# Altium schematic sheet TIMER.SchDoc
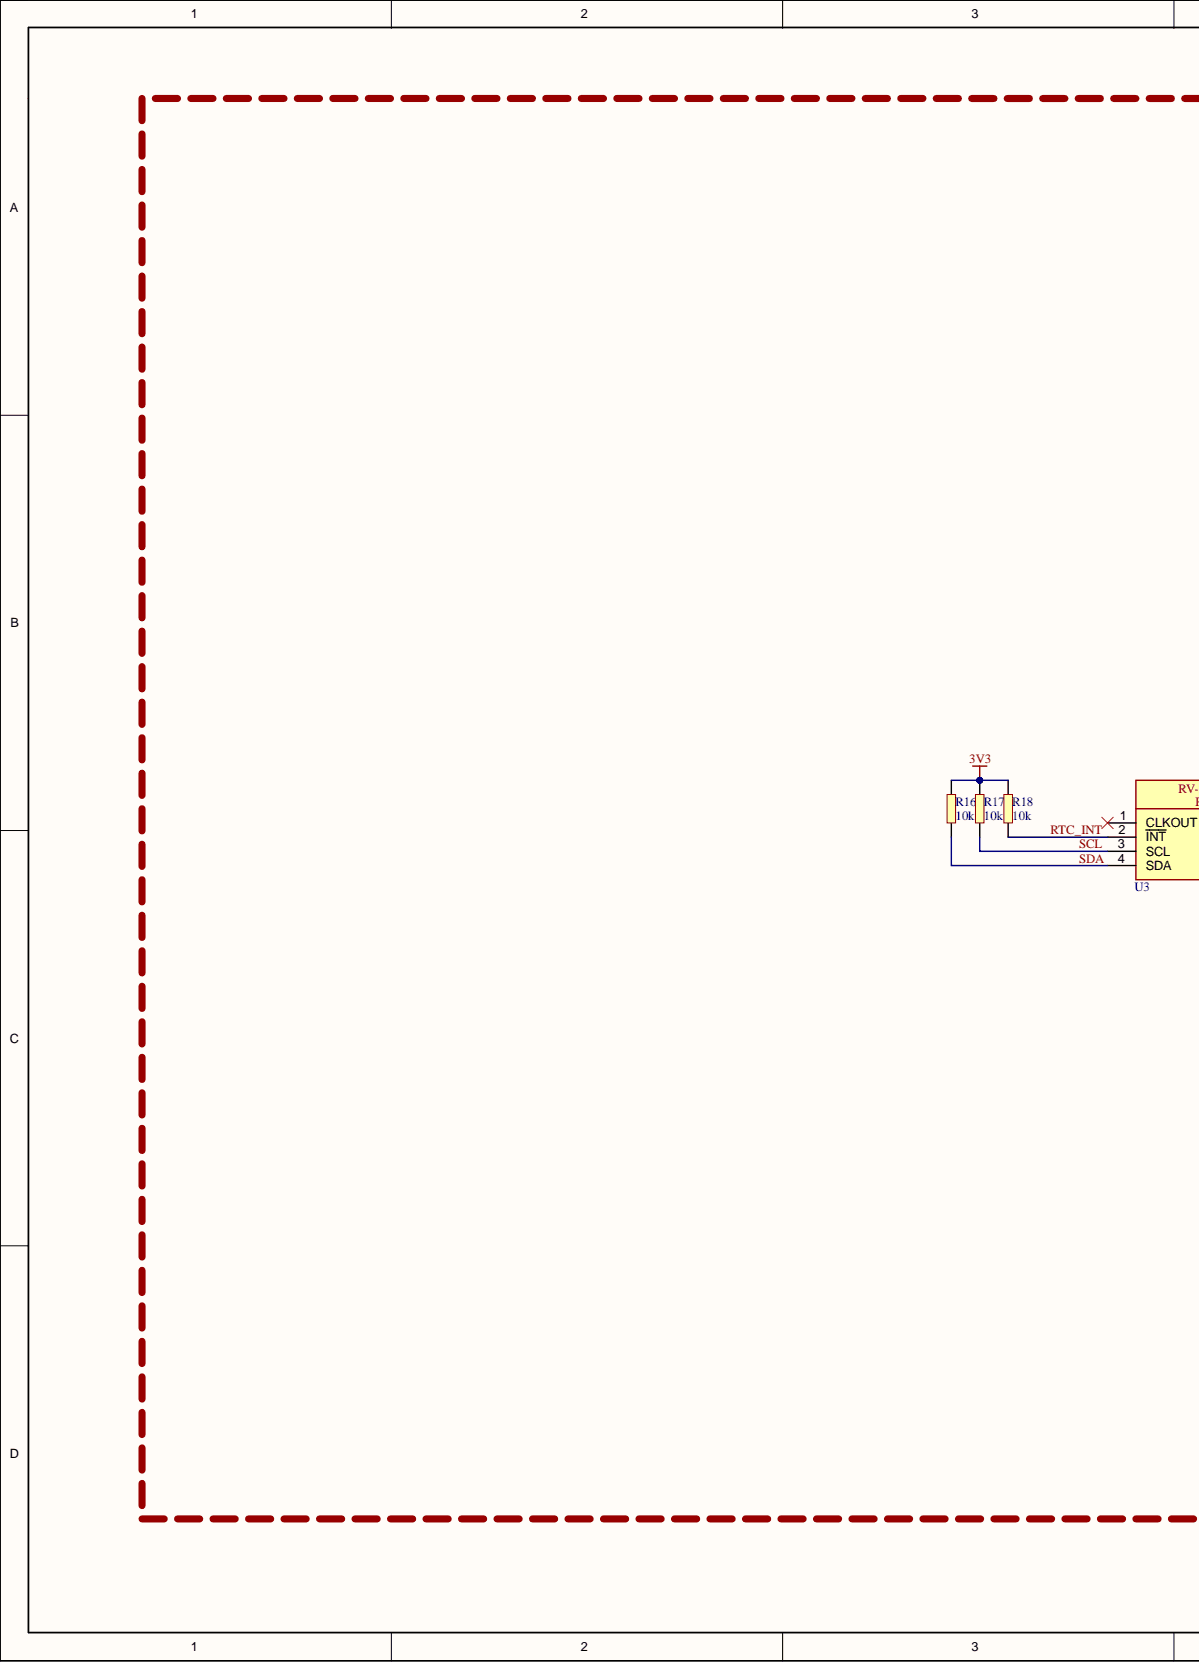
[diagram p0: TIMER.SchDoc, left]
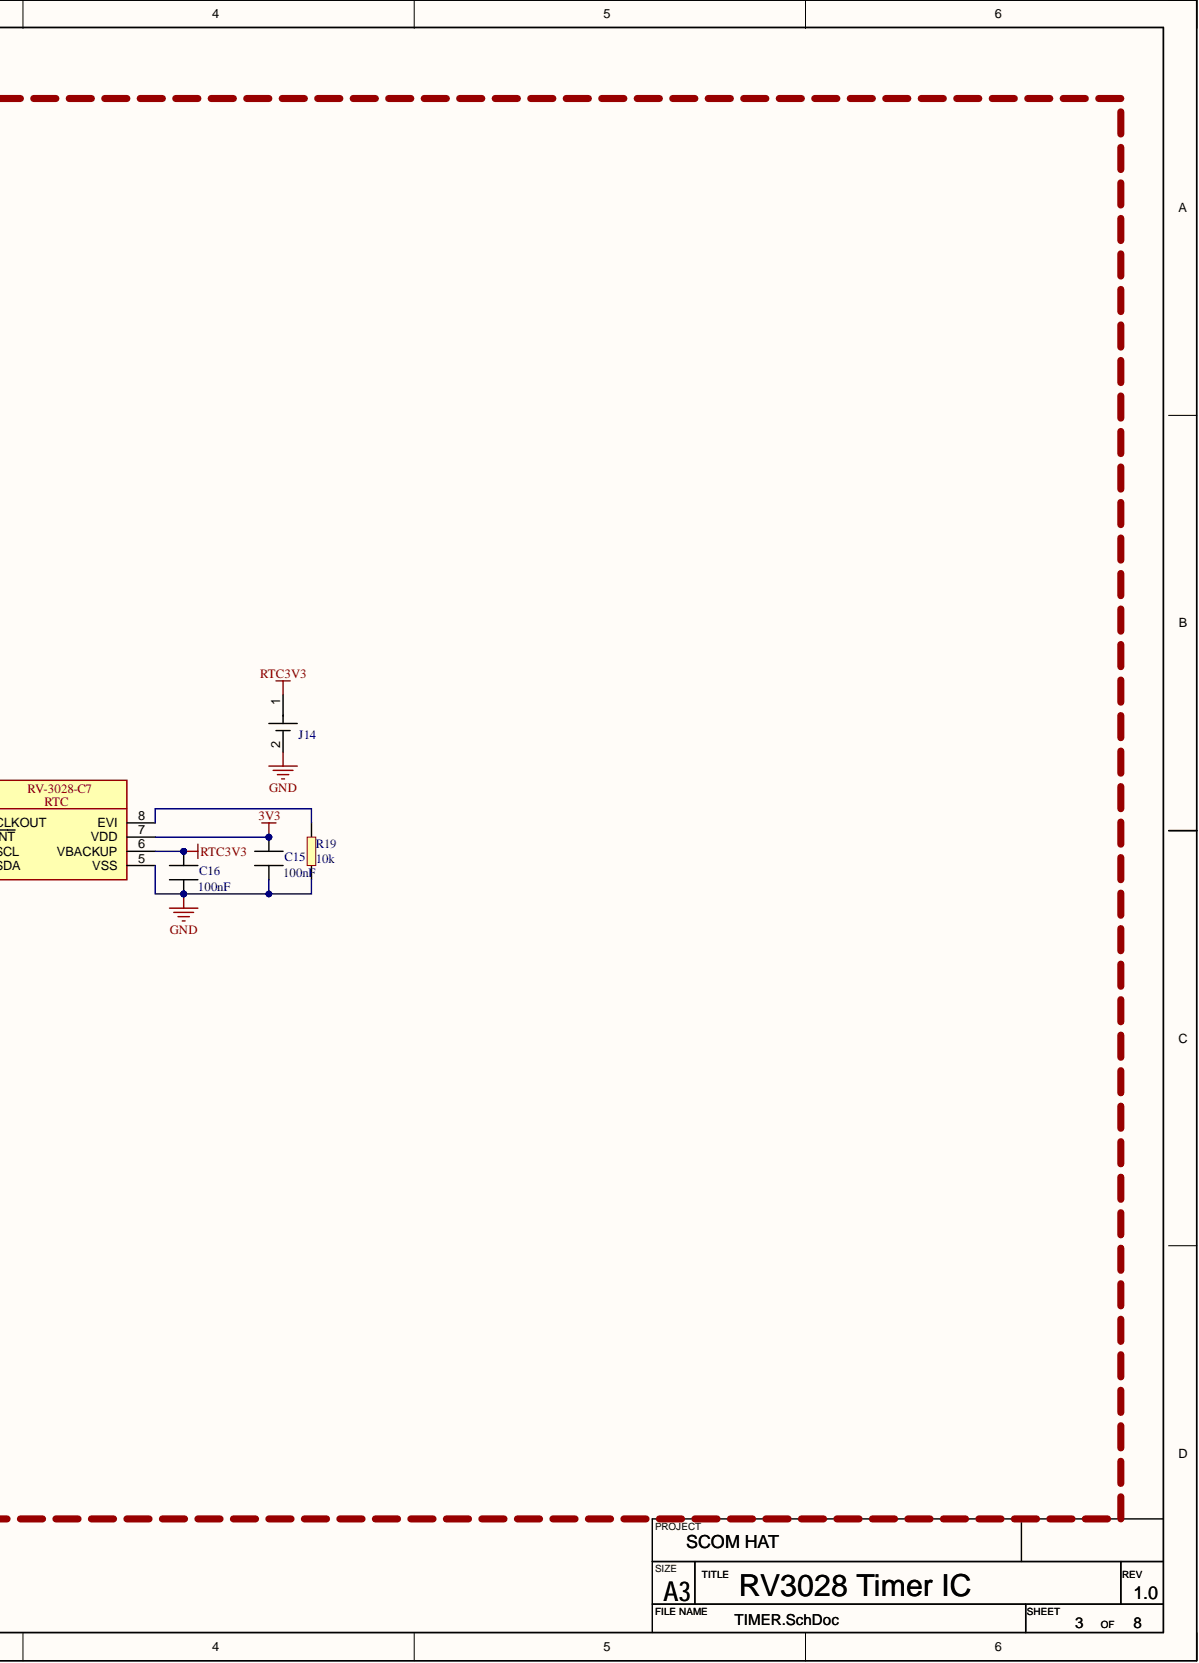
[diagram p1: TIMER.SchDoc, right]

## Parts
C15  value 100nF  [CAPC2012X13L]  (hidden comment: MLCC_0805)
C16  value 100nF  [CAPC2012X13L]  (hidden comment: MLCC_0805)
J14  CR1220-BH  [CR1220-BH]  (value not drawn)
R16  value 10k  [RES_0603]  (hidden comment: ERP_101-1)
R17  value 10k  [RES_0603]  (hidden comment: ERP_101-1)
R18  value 10k  [RES_0603]  (hidden comment: ERP_101-1)
R19  value 10k  [RES_0603]  (hidden comment: ERP_101-1)
U3  RV-3028-C7  [RV-3028-C7]

## Nets
3V3: C15.1 R16.2 R17.2 R18.2 U3.7(VDD)
GND: C15.2 C16.2 J14.2 R19.1 U3.5(VSS)
RTC3V3: C16.1 J14.1 U3.6(VBACKUP)
RTC_INT: R18.1 U3.2(INT)
SCL: R17.1 U3.3(SCL)
SDA: R16.1 U3.4(SDA)
NetR19_2: R19.2 U3.8(EVI)

Not connected: U3 1(CLKOUT)

## Library data of the parts
C15 C16 = MLCC_0805: 1uF 105 0805 (2012 metric) 50V X7R MLCC SMD; Component Kind: Standard; Library Name: Capacitor.SchLib; Package: 0805
J14 = CR1220-BH: BATTERY HOLDER COIN 12.5MM SMD; Manufacturer 1: Keystone Electronics; Component Kind: Standard; Library Name: Connectors.SchLib
R16-R19 = RES_0603: Component Kind: Standard; Description: RES_0603; Library Name: Resistor.SchLib; Package: 0603
U3 = RV-3028-C7: IC RTC CLK/CALENDAR I2C 8SON; Manufacturer 1: Micro Crystal AG; Manufacturer Part Number 1: RV-3028-C7 32.768KHZ 1PPM-TA-QC; Component Kind: Standard; Library Name: RTC.SchLib
